# Altium schematic sheet RuggedBatteryPackV3-OutputStage.SchDoc
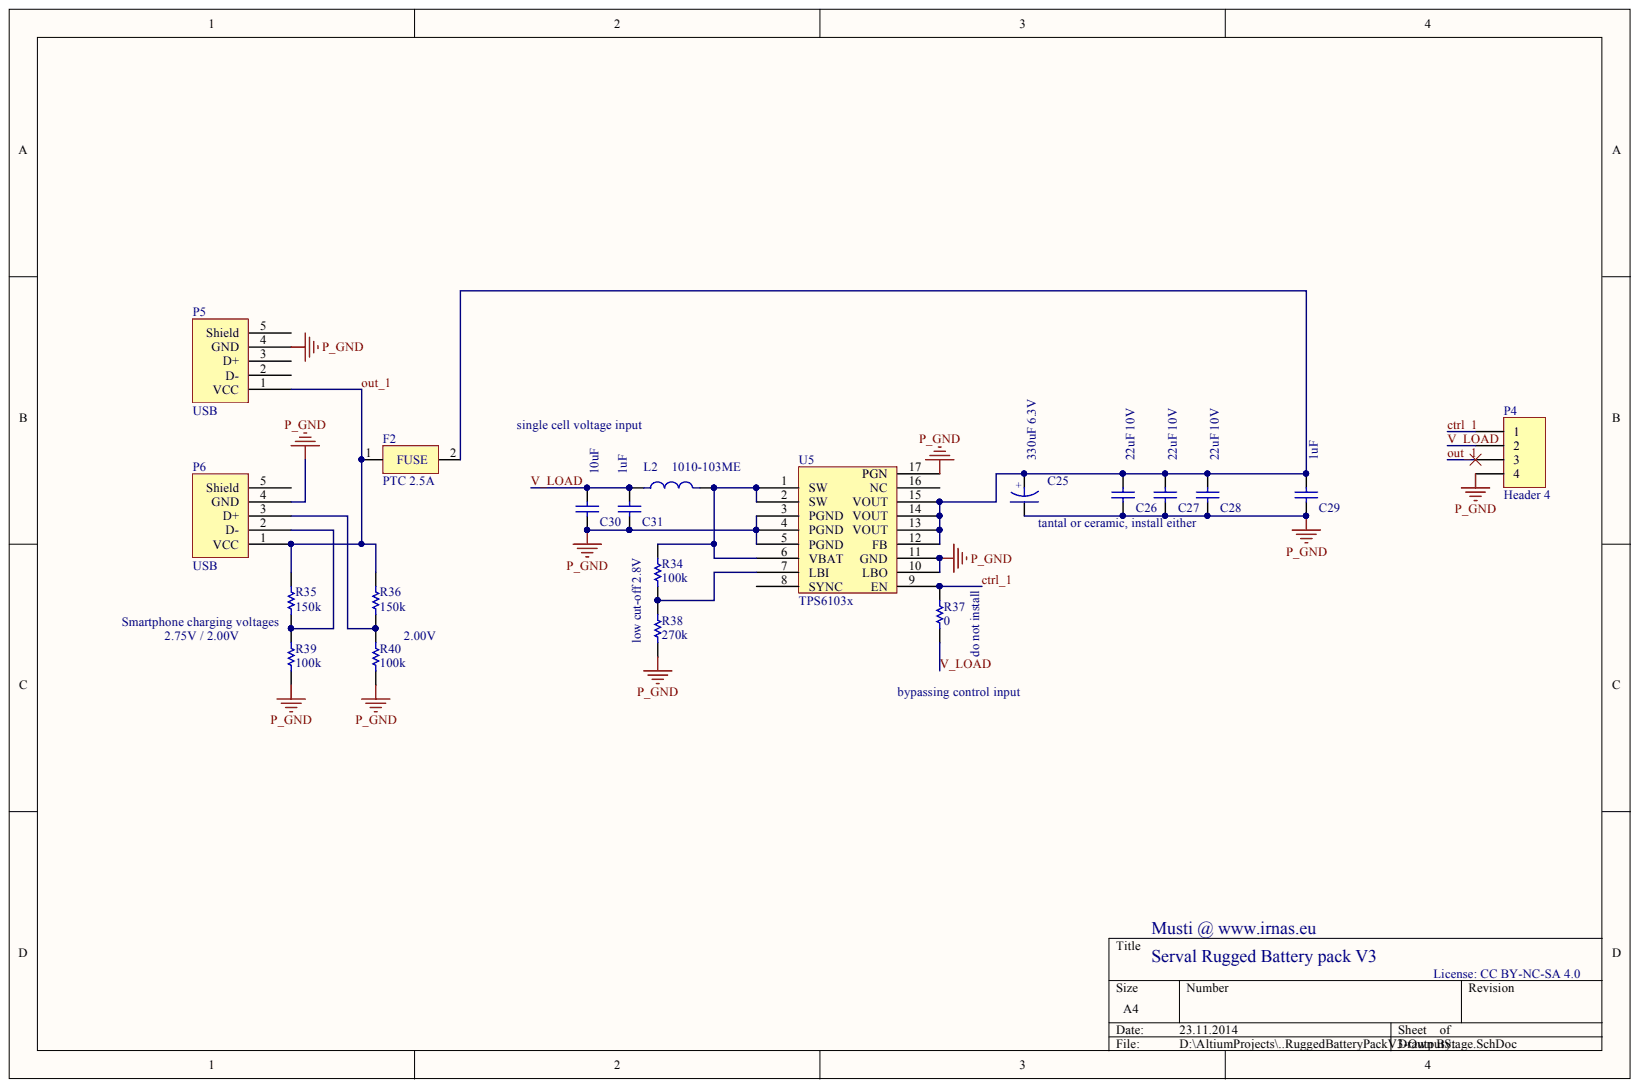
[diagram p0: RuggedBatteryPackV3-OutputStage.SchDoc]

## Parts
C25  330uF 6.3V  [Capacitor D case]
C26  22uF 10V  [1206]
C27  22uF 10V  [1206]
C28  22uF 10V  [1206]
C29  1uF  [RESC2012X06N]
C30  10uF  [RESC2012X06N]
C31  1uF  [RESC2012X06N]
F2  PTC 2.5A  [1210]
L2  1010-103ME  [XAL7070-332]
P4  Header 4  [HDR1X4]
P5  USB  [USB A THT]
P6  USB  [USB A THT]
R34  100k  [RESC0603(1608)_L]
R35  150k  [RESC0603(1608)_L]
R36  150k  [RESC0603(1608)_L]
R37  0  [RESC0603(1608)_L]
R38  270k  [RESC0603(1608)_L]
R39  100k  [RESC0603(1608)_L]
R40  100k  [RESC0603(1608)_L]
U5  TPS6103x  [PWP]

## Nets
P_GND: C25.2 C26.1 C27.1 C28.1 C29.1 C30.1 C31.1 P4.4 P5.4(GND) P6.4(GND) R38.1 R39.1 R40.1 U5.3(PGND) U5.4(PGND) U5.5(PGND) U5.10(LBO) U5.11(GND) U5.17(PGN)
CTRL_1: P4.1 R37.2 U5.9(EN)
OUT_1: F2.1 P4.3 P5.1(VCC) P6.1(VCC) R35.2 R36.2
V_LOAD: C30.2 C31.2 L2.1 P4.2 R37.1
NetC25_1: C25.1 C26.2 C27.2 C28.2 C29.2 F2.2 U5.12(FB) U5.13(VOUT) U5.14(VOUT) U5.15(VOUT)
NetL2_2: L2.2 R34.2 U5.1(SW) U5.2(SW) U5.6(VBAT)
NetP6_2: P6.2(D-) R35.1 R39.2
NetP6_3: P6.3(D+) R36.1 R40.2
NetR34_1: R34.1 R38.2 U5.7(LBI)

Not connected: P5 2(D-) 3(D+) 5(Shield); P6 5(Shield); U5 8(SYNC) 16(NC)

## Library data of the parts
C25 = Capacitor Tantal: T491D226K035AT capacitror; Component Kind: Standard; Library Name: GeneralComponents.SchLib
C26-C31 = CMP-1038-02235-1: CAP 10uF 35V ±20% 1210 (3225 Metric) Thickness 2.1mm SMD; Case-EIA: 1210; Case-Metric: 3225; Component Kind: Standard; Library Name: Altium Content Vault; Max Thickness: 2.1 mm; Rated Voltage: 35 V; Technology: SMT; Tolerance: ±20%; Value: 10uF
F2 = Fuse: 1A fuse; Component Kind: Standard; Library Name: GeneralComponents.SchLib
L2 = Inductor XAL7070-332MEC: Coilcraft XAL; Component Kind: Standard; Library Name: GeneralComponents.SchLib
P4 = Header 4: Header, 4-Pin; Component Kind: Standard; LatestRevisionDate: 17-Jul-2002; LatestRevisionNote: Re-released for DXP Platform.; Library Name: Miscellaneous Connectors.IntLib; Signal Integrity: Connector; Publisher: Altium Limited
P5 P6 = USB: USB A standard receptacle; Component Kind: Standard; Library Name: GeneralComponents.SchLib
R34-R40 = CMP-1012-00026-1: 1k 0.1W 5% 0603 (1608 Metric)  SMD; Case-EIA: 0603; Case-Metric: 1608; Value: 10.00 Ohm; Component Kind: Standard; Library Name: Altium Content Vault; Power: 0.1 W; Technology: SMT; Tolerance: 5 %
U5 = TPS6103x: TPS6103x step-up regulator; Component Kind: Standard; Library Name: GeneralComponents.SchLib
